# Altium schematic sheet MCU.SchDoc(MCU)
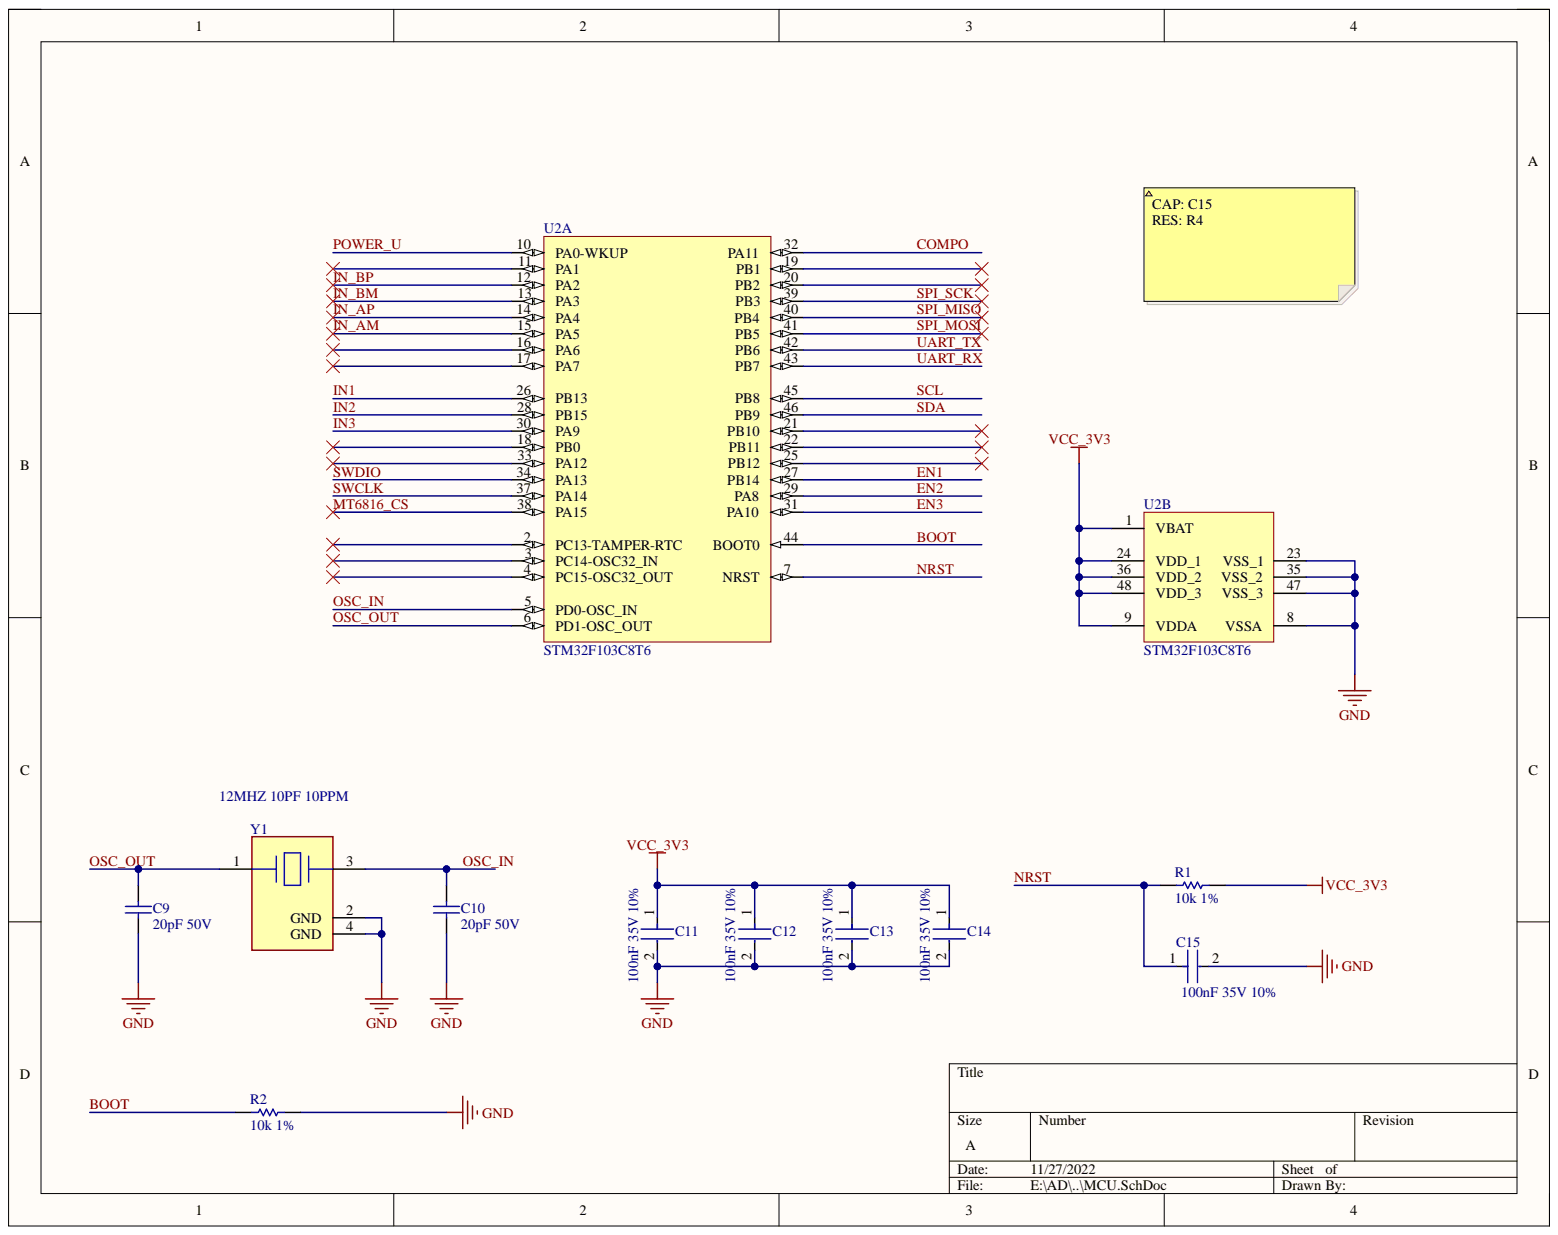
[diagram p0: MCU.SchDoc]

## Parts
C9  20pF 50V  Capacitance: 20pF  [CAPC1608X90X30LL10T20]
C10  20pF 50V  Capacitance: 20pF  [CAPC1608X90X30LL10T20]
C11  100nF 35V 10%  Capacitance: 100nF  Tolerance: 10%  Voltage: 35V  [FP-0402-L_1_0_0_05-W_0_5-IPC_A]
C12  100nF 35V 10%  Capacitance: 100nF  Tolerance: 10%  Voltage: 35V  [FP-0402-L_1_0_0_05-W_0_5-IPC_A]
C13  100nF 35V 10%  Capacitance: 100nF  Tolerance: 10%  Voltage: 35V  [FP-0402-L_1_0_0_05-W_0_5-IPC_A]
C14  100nF 35V 10%  Capacitance: 100nF  Tolerance: 10%  Voltage: 35V  [FP-0402-L_1_0_0_05-W_0_5-IPC_A]
C15  100nF 35V 10%  Capacitance: 100nF  Voltage: 35V  [FP-0402-L_1_0_0_05-W_0_5-IPC_A]
R1  10k 1%  [RESC2013X70X40ML20T20]
R2  10k 1%  [RESC2013X70X40ML20T20]
U2  STM32F103C8T6  [STM-LQFP48_L]  (parts A,B)
Y1  12MHZ 10PF 10PPM  [FP-ABM3B-MFG]

## Nets
GND: C9.1 C10.1 C11.2 C12.2 C13.2 C14.2 C15.2 R2.2 U2.8(VSSA) U2.23(VSS_1) U2.35(VSS_2) U2.47(VSS_3) Y1.2(GND) Y1.4(GND)
VCC_3V3: C11.1 C12.1 C13.1 C14.1 R1.2 U2.1(VBAT) U2.9(VDDA) U2.24(VDD_1) U2.36(VDD_2) U2.48(VDD_3)
BOOT: R2.1 U2.44(BOOT0)
COMPO: U2.32(PA11)
EN1: U2.27(PB14)
EN2: U2.29(PA8)
EN3: U2.31(PA10)
IN1: U2.26(PB13)
IN2: U2.28(PB15)
IN3: U2.30(PA9)
IN_AM: U2.15(PA5)
IN_AP: U2.14(PA4)
IN_BM: U2.13(PA3)
IN_BP: U2.12(PA2)
MT6816_CS: U2.38(PA15)
NRST: C15.1 R1.1 U2.7(NRST)
OSC_IN: C10.2 U2.5(PD0-OSC_IN) Y1.3
OSC_OUT: C9.2 U2.6(PD1-OSC_OUT) Y1.1
POWER_U: U2.10(PA0-WKUP)
SCL: U2.45(PB8)
SDA: U2.46(PB9)
SPI_MISO: U2.40(PB4)
SPI_MOSI: U2.41(PB5)
SPI_SCK: U2.39(PB3)
SWCLK: U2.37(PA14)
SWDIO: U2.34(PA13)
UART_RX: U2.43(PB7)
UART_TX: U2.42(PB6)

Not connected: U2 2(PC13-TAMPER-RTC) 3(PC14-OSC32_IN) 4(PC15-OSC32_OUT) 11(PA1) 16(PA6) 17(PA7) 18(PB0) 19(PB1) 20(PB2) 21(PB10) 22(PB11) 25(PB12) 33(PA12)

## Library data of the parts
C9 C10 = CMP-2000-06100-1: Chip Capacitor, 20 pF, +/- 5%, 50 V, -55 to 85 degC, 0603 (1608 Metric), RoHS, Tape and Reel; Manufacturer: Samsung Electro-Mechanics; Dielectric: C0G; Case Size/Dimension: 0603; Component Kind: Standard; Datasheet URL: http://www.samsungsem.com/kr/support/product-search/mlcc/__icsFiles/afieldfile/2016/08/18/S_CL10C200JB8NNNC.pdf; Datasheet Version: 06/2016; Library Name: Altium Content Vault; Manufacturer URL: http://www.samsungsem.com/global/index.jsp; Mounting Technology: SurfaceMount; Package Description: 2-Pin Surface Mount Device, Body 1.60 x 0.80 mm; Package Reference: 0603; Rated Voltage: 50V; Tolerance (%): ±5%
C11-C15 = CMP-2000-06323-3: Dielectric: X5R; Case Code (Imperial): 0402; Case Code (Metric): 1005; Case/Package: 0402; Component Kind: Standard; Construction: Flat; Depth: 0.5mm; Description: 100nF 35V 10%; Halogen Free: Halogen Free; Height: 0.5mm; Length: 1mm; Library Name: Altium Content Vault; Max Operating Temperature: 85°C; Min Operating Temperature: -55°C; Mount: Surface Mount; Number of Pins: 2; Package Quantity: 1; Packaging: Tape and Reel; Radiation Hardening: No; REACH SVHC: No SVHC; Resistor Type: Ceramic; RoHS Compliant: Yes; Series: M; Simulation: GMK105BJ104_V-F; Termination: SMD/SMT; Thickness: 0.022inch; Voltage Rating: 35V; Voltage Rating (DC): 35V; Width: 0.02inch
  C15: Tolerance: 10%
R1 R2 = CMP-2001-04376-1: Manufacturer: Panasonic; Part Number: ERJ-6ENF1002V; Component Kind: Standard; Library Name: Altium Content Vault
U2 = CMP-0237-00215-3: ARM Cortex-M3 32-bit MCU, 64 KB Flash, 20 KB Internal RAM, 37 I/Os, 48-pin LQFP, -40 to 85 degC, Tray; A/D Converter: 2x12-bit (10 Channel); FLASH Size (Prog) (kB): 64; I/Os (High Current): 37; Internal RAM Size (kB): 20; Operating Frequency(F) (Processor speed) (MHz): 72; Supply Voltage(Vcc) min (V): 2; Component Kind: Standard; ComponentLink1Description: Manufacturer URL; ComponentLink1URL: http://www.st.com/web/en/home.html; ComponentLink2Description: Datasheet; ComponentLink2URL: http://www.st.com/st-web-ui/static/active/en/resource/technical/document/datasheet/CD00161566.pdf; ComponentLink3Description: Package Specification; ComponentLink3URL: http://www.st.com/st-web-ui/static/active/en/resource/technical/document/datasheet/DM00058181.pdf; Core: ARM Cortex-M3; DatasheetVersion: Rev. 16; Library Name: Altium Content Vault; Mounting Technology: Surface Mount; PackageDescription: 48-Pin Low Profile Quad Flat Package, 7 x 7 mm Body, 0.5 mm Pitch; PackageReference: LQFP48; PackageVersion: Rev. 6, 06/2013; Packing: Tray; PartNumber: STM32F103C8T6
Y1 = CMP-27762-011386-1: Crystal 12MHz ±10ppm 10pF SMD-4 5mm x 3.2mm; ESR (Equivalent Series Resistance): 70R; Case/Package: CSMD; Component Kind: Standard; Depth: 3.2mm; Frequency: 12MHz; Frequency Stability: 10ppm; Frequency Tolerance: 10ppm; Height: 0.043inch; Lead Free: Lead Free; Length: 5mm; Library Name: Altium Content Vault; Load Capacitance: 10pF; Max Operating Temperature: 60°C; Min Operating Temperature: -10°C; Mount: Surface Mount; Number of Pins: 4; Operating Frequency: 12 MHz; Package Quantity: 1000; Packaging: Tape and Reel; REACH SVHC: No SVHC; Resistor Type: Ceramic; Series: ABM3B; Series Resistance: 70R; Termination: SMD/SMT; Tolerance: 10ppm; Width: 0.126inch
